# Altium schematic sheet IO.SchDoc
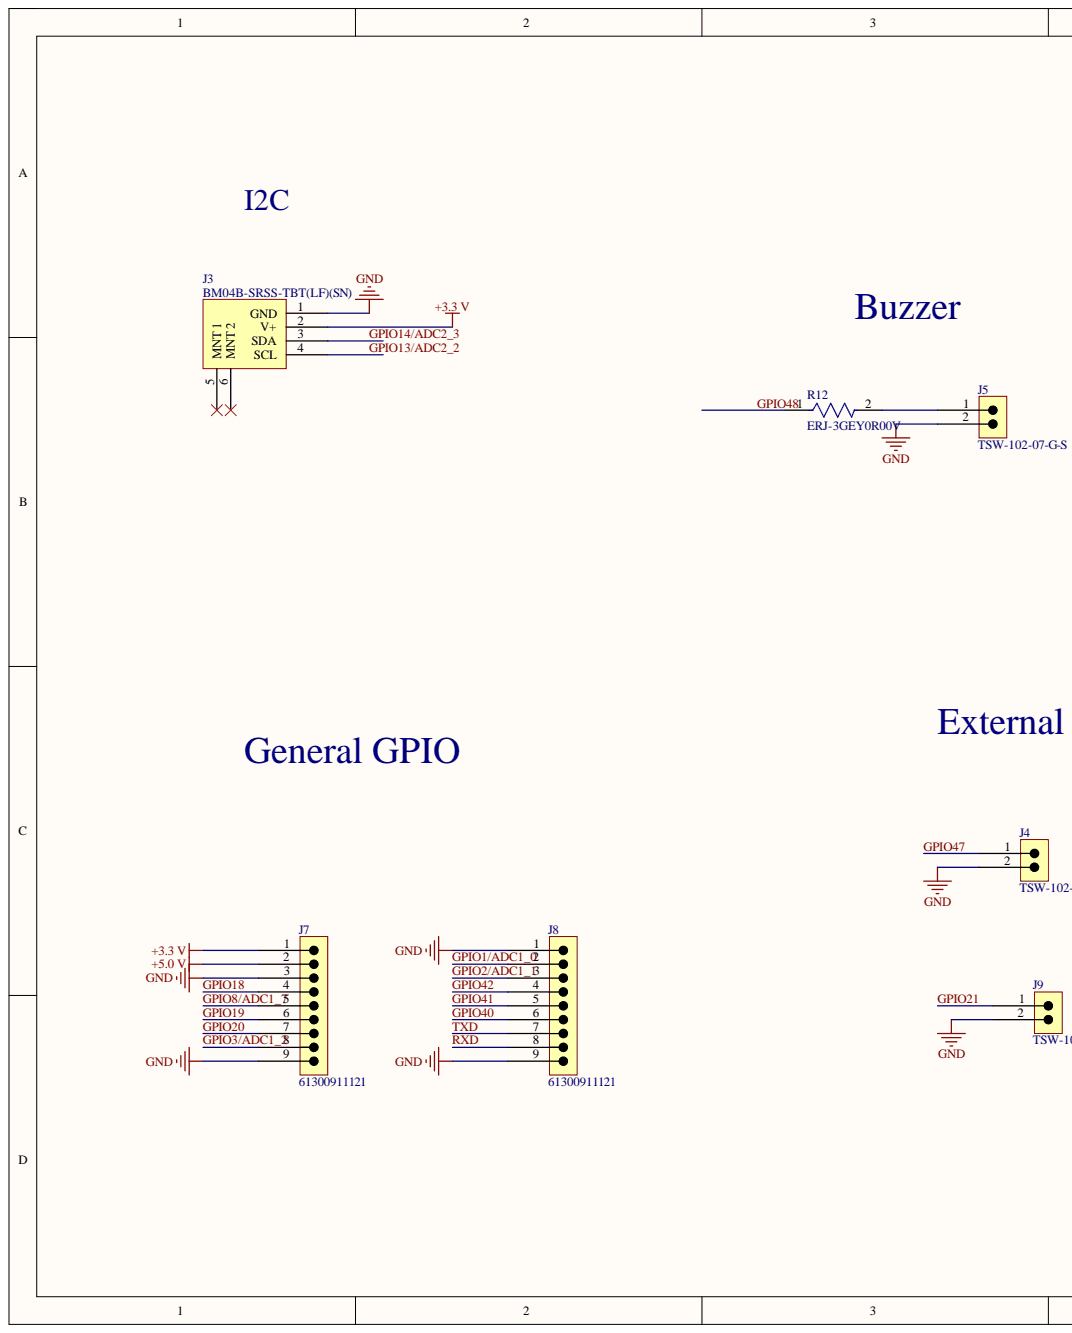
[diagram p0: IO.SchDoc, left]
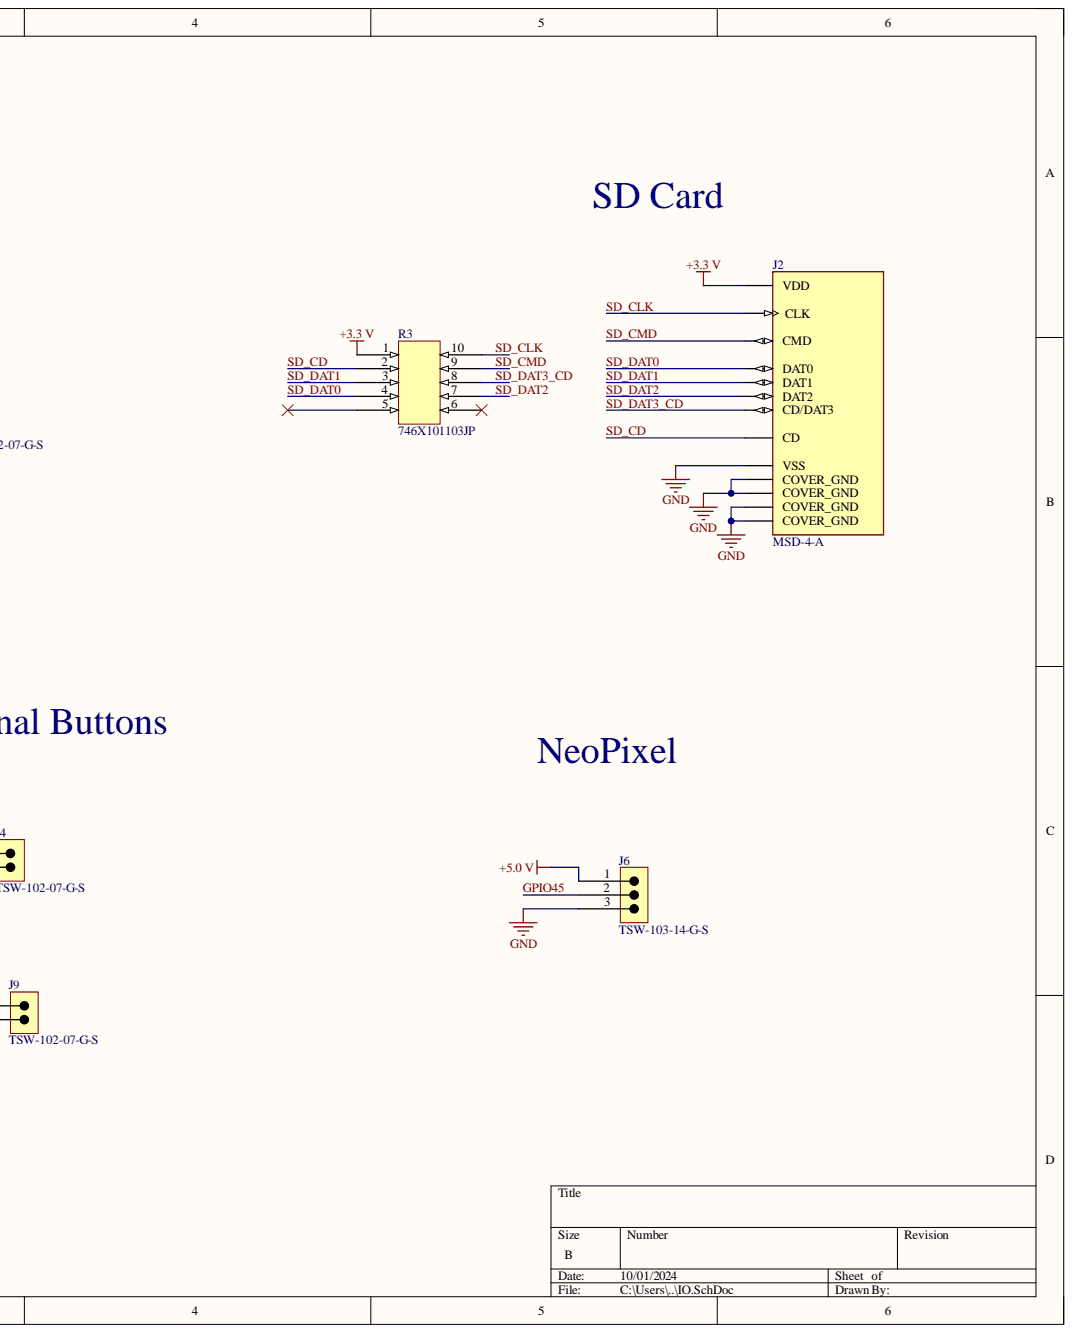
[diagram p1: IO.SchDoc, right]

## Parts
J2  MSD-4-A  [CUI_MSD-4-A]
J3  BM04B-SRSS-TBT(LF)(SN)  [FP-BM04B-SRSS-TBT_LF_SN-MFG]
J4  TSW-102-07-G-S  [FP-TSW-102-07-G-S-MFG]
J5  TSW-102-07-G-S  [FP-TSW-102-07-G-S-MFG]
J6  TSW-103-14-G-S  [FP-TSW-103-14-G-S-MFG]
J7  61300911121  [61300911121]
J8  61300911121  [61300911121]
J9  TSW-102-07-G-S  [FP-TSW-102-07-G-S-MFG]
R3  746X101103JP  [CAF-127P640X310-10N]
R12  ERJ-3GEY0R00V  [FP-ERJ-3GEY0R00V-IPC_A]

## Nets
+3.3 V: J2.4(VDD) J3.2(V+) J7.1 R3.1
+5.0 V: J6.1 J7.2
GND: J2.6(VSS) J2.G1(COVER_GND) J2.G2(COVER_GND) J2.G3(COVER_GND) J2.G4(COVER_GND) J3.1(GND) J4.2 J5.2 J6.3 J7.3 J7.9 J8.1 J8.9 J9.2
GPIO1/ADC1_0: J8.2
GPIO2/ADC1_1: J8.3
GPIO3/ADC1_2: J7.8
GPIO8/ADC1_7: J7.5
GPIO13/ADC2_2: J3.4(SCL)
GPIO14/ADC2_3: J3.3(SDA)
GPIO18: J7.4
GPIO19: J7.6
GPIO20: J7.7
GPIO21: J9.1
GPIO40: J8.6
GPIO41: J8.5
GPIO42: J8.4
GPIO45: J6.2
GPIO47: J4.1
GPIO48: R12.1
RXD: J8.8
SD_CD: J2.CD R3.2
SD_CLK: J2.5(CLK) R3.10
SD_CMD: J2.3(CMD) R3.9
SD_DAT0: J2.7(DAT0) R3.4
SD_DAT1: J2.8(DAT1) R3.3
SD_DAT2: J2.1(DAT2) R3.7
SD_DAT3_CD: J2.2(CD/DAT3) R3.8
TXD: J8.7
NetJ5_1: J5.1 R12.2

Not connected: J3 5(MNT_1) 6(MNT_2); R3 5 6

## Library data of the parts
J2 = CMP-002-00078-2: Micro SD Card Connector, 9 Positions, Connector and Ejector, Push In, Auto Eject Out, Surface Mount, 1.8 mm Height Above Board, Gold Flash; MF: CUI Devices; Case/Package: None; Check_prices: https://www.snapeda.com/parts/MSD-4-A/CUI+Devices/view-part/?ref=eda; Component Kind: Standard; Component Type: Standard; CUI_purchase_URL: https://www.cuidevices.com/product/interconnect/connectors/memory-card-connectors/sd-card-connectors/msd-4-a?utm_source=snapeda.com&utm_medium=referral&utm_campaign=snapedaBOM; Design Item ID: MSD-4-A; Library Name: C0514760 C0514760; MP: MSD-4-A; Pins: 13; SnapEDA_Link: https://www.snapeda.com/parts/MSD-4-A/CUI+Devices/view-part/?ref=snap; Source: MSD-4-A.SchLib
J3 = CMP-002-00076-4: 1.0mm Pitch, Ultra-Compact, Low Profile Design, Interchangeable Shrouded Header; Component Kind: Standard; Library Name: C0514760 C0514760
J4 J5 J9 = CMP-00020-2: Board Connector, 2 Contact(s), 1 Row(s), Male, Straight, 0.1 inch Pitch, Solder Terminal, Black Insulator; Additional Feature: E.L.P.; Body/Shell Style: RECEPTACLE; Contact Pattern: RECTANGULAR; Contact Style: SQ PIN-SKT; DIN Conformance: No; Mating Information: MULTIPLE MATING PARTS AVAILABLE; Number Of Connectors: 1; Number of Contacts: 2; Option: General Purpose; Plating Thickness: 10uin; Reference Standard: UL; Reliability: COMMERCIAL; Body Breadth: 0.1inch; Body Depth: 0.1inch; Component Kind: Standard; Connector Type: BOARD CONNECTOR; Contact Finish - Mating: GOLD (10) OVER NICKEL (50); Contact Finish - Termination: Gold (Au) - with Nickel (Ni) barrier; Contact Gender: Male; Contact Material: Bronze; Filter Feature: No; Flammability Rating: UL94 V-0; IEC Conformance: No; Insulation Color: Black; Insulation Material: Glass; JESD-609 Code: e4; Library Name: C0514760 C0514760; Mating Contact Pitch: 0.1inch; MIL Conformance: No; Mixed Contacts: No; Mounting Style: STRAIGHT; Mounting Technology: Board; Number Of PCB Rows: 1; Number of Rows Loaded: 1; PCB Contact Pattern: RECTANGULAR; Reach SVHC Compliant: Yes; RoHS Compliant: Yes; Terminal Length: 0.1inch; Terminal Pitch: 2.54mm; Termination: Solder
J6 = CMP-00025-3: Board Connector, 3 Contact(s), 1 Row(s), Male, Straight, 0.1 inch Pitch, Solder Terminal, Black Insulator, Receptacle; Additional Feature: E.L.P.; Contact Pattern: RECTANGULAR; Contact Style: SQ PIN-SKT; DIN Conformance: No; Mating Information: MULTIPLE MATING PARTS AVAILABLE; Number Of Connectors: 1; Number of Contacts: 3; Option: General Purpose; Plating Thickness: 10uin; Reference Standard: UL; Reliability: COMMERCIAL; Body Breadth: 0.1inch; Body Depth: 0.1inch; Body/Shell Style: RECEPTACLE; Component Kind: Standard; Connector Type: BOARD CONNECTOR; Contact Finish - Mating: GOLD (10) OVER NICKEL (50); Contact Finish - Termination: Gold (Au) - with Nickel (Ni) barrier; Contact Gender: Male; Contact Material: Bronze; Filter Feature: No; IEC Conformance: No; Insulation Color: Black; Insulation Material: Glass; JESD-609 Code: e4; Library Name: C0514760 C0514760; Mating Contact Pitch: 0.1inch; MIL Conformance: No; Mixed Contacts: No; Mounting Style: STRAIGHT; Mounting Technology: Board; Number Of PCB Rows: 1; Number of Rows Loaded: 1; PCB Contact Pattern: RECTANGULAR; Reach SVHC Compliant: Yes; RoHS Compliant: Yes; Terminal Length: 0.11inch; Terminal Pitch: 2.54mm; Termination: Solder
J7 J8 = CMP-00023-4: Board Connector, 9 Contact(s), 1 Row(s), Male, Straight, 0.1 inch Pitch, Solder Terminal, Locking, Black Insulator, Plug; Body Length: 0.9inch; Contact Pattern: RECTANGULAR; Contact Resistance: 20mR; Contact Style: SQ PIN-SKT; Dielectric Withstanding Voltage (AC): 500V; Insulation Resistance: 1GR; Number Of Connectors: 1; Number of Contacts: 9; Rated Current (Signal): 3A; Reference Standard: UL; Reliability: COMMERCIAL; Body Breadth: 0.1inch; Body Depth: 0.1inch; Body/Shell Style: PLUG; Component Kind: Standard; Connector Type: BOARD CONNECTOR; Contact Finish - Mating: GOLD; Contact Finish - Termination: Gold (Au) - with Nickel (Ni) barrier; Contact Gender: Male; Contact Material: Copper Alloy; Insulation Color: Black; Insulation Material: Polybutylene; JESD-609 Code: e4; Library Name: C0514760 C0514760; Mating Contact Pitch: 0.1inch; Max Operating Temperature: 125°C; Min Operating Temperature: -40°C; Mounting Option 1: LOCKING; Mounting Style: STRAIGHT; Mounting Technology: Board; Number of Rows Loaded: 1; PCB Contact Pattern: RECTANGULAR; Reach SVHC Compliant: Yes; RoHS Compliant: Yes; Terminal Length: 0.118inch; Terminal Pitch: 2.54mm; Termination: Solder
R3 = CMP-00038-1: Array/Network Resistor, Bussed, Metal Glaze/thick Film, 0.063W, 10000ohm, 25V, 5% +/-Tol, 200ppm/Cel, Surface Mount, 0612; Channels: 1; Element Power Dissipation: 31mW; First Element Resistance: 10kR; Number of Elements: 8; Package Height: 0.6mm; Package Length: 3.2mm; Package Width: 1.6mm; Power: 248mW; Rated Temperature: 70°C; Temperature Coefficient: 200ppm/°C; Value: 10kR; Case/Package: 0613; Component Kind: Standard; Construction: Chip; JESD-609 Code: e3; Library Name: C0514760 C0514760; Max Operating Temperature: 125°C; Min Operating Temperature: -55°C; Mounting Technology: Surface Mount; Network Type: BUSSED; Package Shape: Rectangular; Package Style: SMTMeter; Packaging: Tape and Reel; Pins: 10; Reach SVHC Compliant: Yes; Resistor Type: ARRAY/NETWORK RESISTOR; RoHS Compliant: Yes; Technology: METAL GLAZE/THICK FILM; Terminal Finish: Nickel; Termination: Wraparound; Tolerance: 5%; Working Voltage: 25V
R12 = CMP-00039-1: Fixed Resistor, Metal Glaze/thick Film, 0.1W, 0ohm, Surface Mount, 0603; Package Height: 0.45mm; Package Length: 1.6mm; Package Width: 0.8mm; Power: 100mW; Rated Temperature: 70°C; Reference Standard: AEC-Q200; Value: 0R; Case/Package: 0603; Component Kind: Standard; Construction: Rectangular; JESD-609 Code: e3; Library Name: C0514760 C0514760; Max Operating Temperature: 155°C; Min Operating Temperature: -55°C; Mounting Technology: Surface Mount; Package Shape: Rectangular; Package Style: SMTMeter; Packaging: Tape and Reel; Pins: 2; Reach SVHC Compliant: Yes; Resistor Type: FIXED RESISTOR; RoHS Compliant: Yes; Technology: METAL GLAZE/THICK FILM; Terminal Finish: Nickel; Termination: Wraparound
